# Altium schematic sheet POWER_PCie.SchDoc
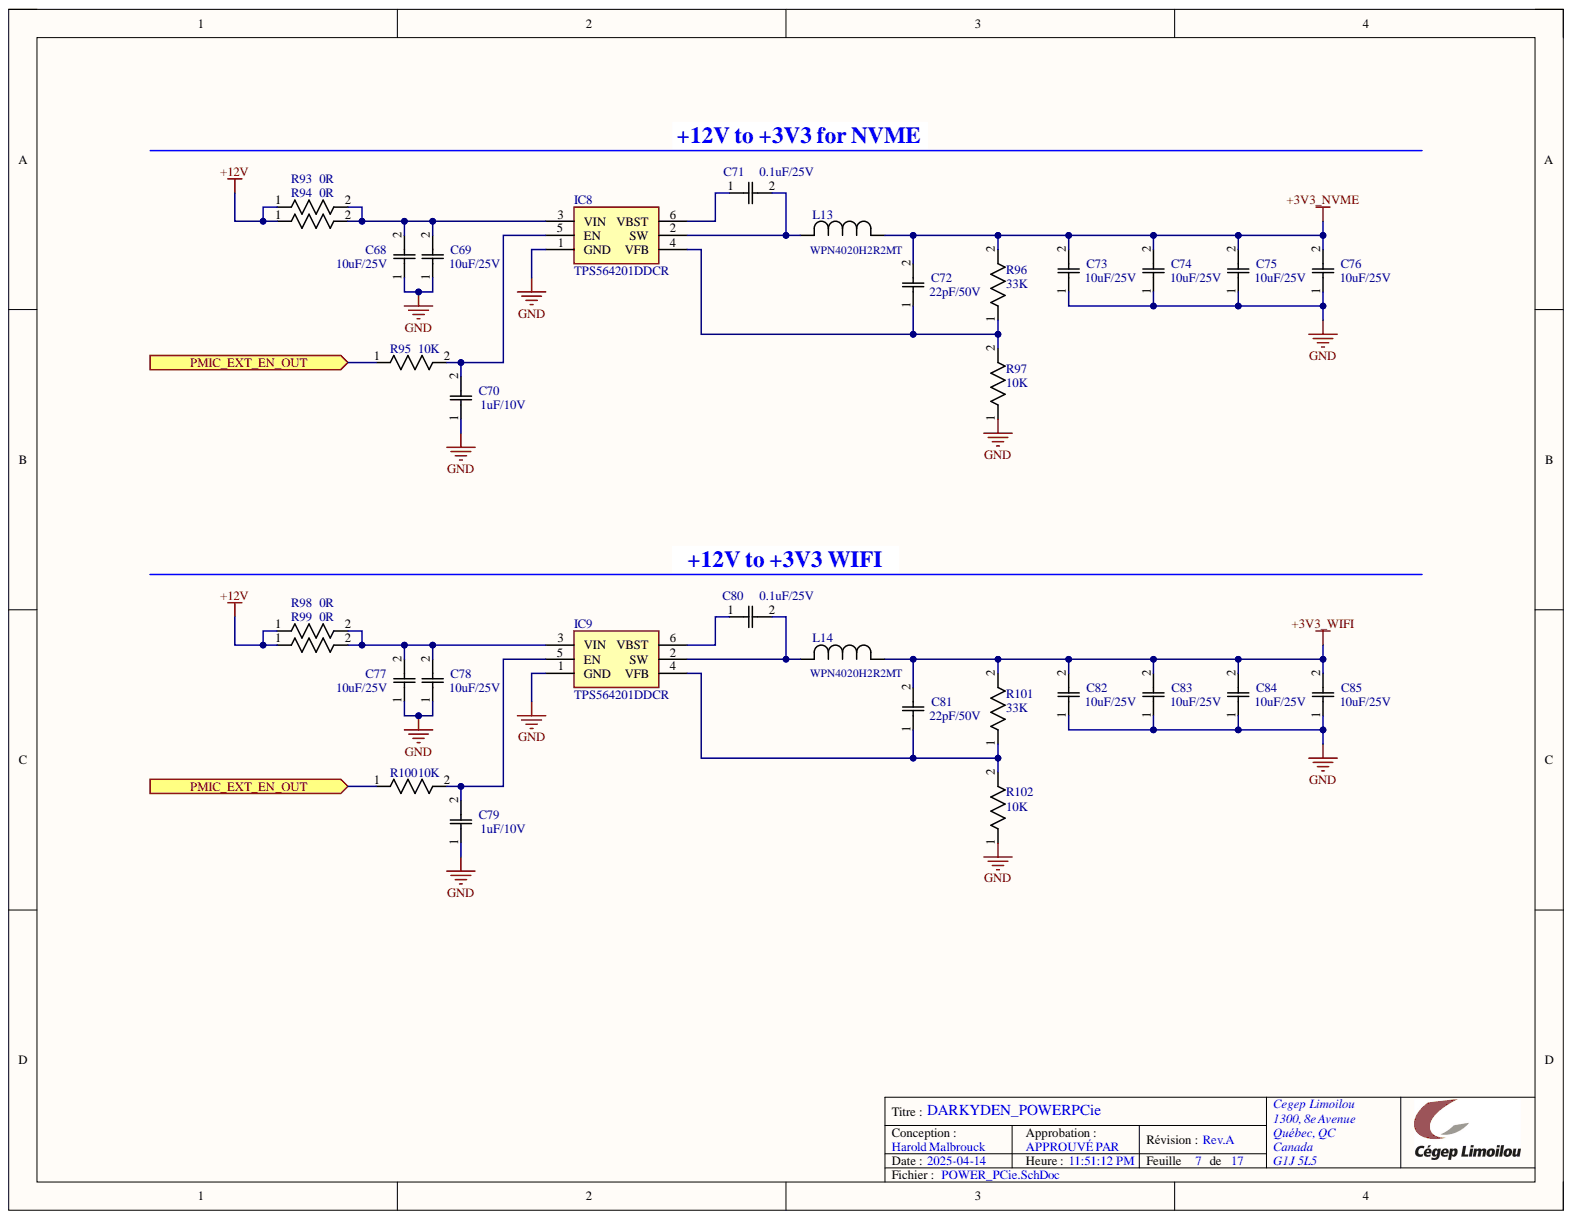
[diagram p0: POWER_PCie.SchDoc]

## Parts
C68  10uF/25V  [CAP_CC0805_YAG]
C69  10uF/25V  [CAP_CC0805_YAG]
C70  1uF/10V  [CAP_CC0805_YAG]
C71  0.1uF/25V  [CAP_CC0805_YAG]
C72  22pF/50V  [CAP_CC0805_YAG]
C73  10uF/25V  [CAP_CC0805_YAG]
C74  10uF/25V  [CAP_CC0805_YAG]
C75  10uF/25V  [CAP_CC0805_YAG]
C76  10uF/25V  [CAP_CC0805_YAG]
C77  10uF/25V  [CAP_CC0805_YAG]
C78  10uF/25V  [CAP_CC0805_YAG]
C79  1uF/10V  [CAP_CC0805_YAG]
C80  0.1uF/25V  [CAP_CC0805_YAG]
C81  22pF/50V  [CAP_CC0805_YAG]
C82  10uF/25V  [CAP_CC0805_YAG]
C83  10uF/25V  [CAP_CC0805_YAG]
C84  10uF/25V  [CAP_CC0805_YAG]
C85  10uF/25V  [CAP_CC0805_YAG]
IC8  TPS564201DDCR  [SOT95P280X110-6N]
IC9  TPS564201DDCR  [SOT95P280X110-6N]
L13  WPN4020H2R2MT  [IND_WPN4020H_SNL]
L14  WPN4020H2R2MT  [IND_WPN4020H_SNL]
R93  0R  [RES_0816_SUS]
R94  0R  [RES_0816_SUS]
R95  10K  [RES_0816_SUS]
R96  33K  [RES_0816_SUS]
R97  10K  [RES_0816_SUS]
R98  0R  [RES_0816_SUS]
R99  0R  [RES_0816_SUS]
R100  10K  [RES_0816_SUS]  (value not drawn)
R101  33K  [RES_0816_SUS]
R102  10K  [RES_0816_SUS]

## Ports
PMIC_EXT_EN_OUT

## Nets
+3V3_NVME: C72.2 C73.2 C74.2 C75.2 C76.2 L13.1 R96.2
+3V3_WIFI: C81.2 C82.2 C83.2 C84.2 C85.2 L14.1 R101.2
+12V: R93.1 R94.1 R98.1 R99.1
GND: C68.1 C69.1 C70.1 C73.1 C74.1 C75.1 C76.1 C77.1 C78.1 C79.1 C82.1 C83.1 C84.1 C85.1 IC8.1(GND) IC9.1(GND) R97.1 R102.1
PMIC_EXT_EN_OUT: R95.1 R100.1 ; port PMIC_EXT_EN_OUT
NetC68_2: C68.2 C69.2 IC8.3(VIN) R93.2 R94.2
NetC70_2: C70.2 IC8.5(EN) R95.2
NetC71_1: C71.1 IC8.6(VBST)
NetC71_2: C71.2 IC8.2(SW) L13.2
NetC72_1: C72.1 IC8.4(VFB) R96.1 R97.2
NetC77_2: C77.2 C78.2 IC9.3(VIN) R98.2 R99.2
NetC79_2: C79.2 IC9.5(EN) R100.2
NetC80_1: C80.1 IC9.6(VBST)
NetC80_2: C80.2 IC9.2(SW) L14.2
NetC81_1: C81.1 IC9.4(VFB) R101.1 R102.2

## Library data of the parts
C68-C85 = Capacitor_0805: No Description Available; Mfr_Name: Yageo; Component Kind: Standard; Library Name: Capacitor_0805.SchLib
IC8 IC9 = TPS564201DDCR: Integrated Circuit; Manufacturer_Name: Texas Instruments; Arrow Part Number: TPS564201DDCR; Arrow Price/Stock: https://www.arrow.com/en/products/tps564201ddcr/texas-instruments?region=nac; Component Kind: Standard; Datasheet Link: http://www.ti.com/lit/gpn/tps564201; Height: 1.1mm; Library Name: SamacSys.SchLib; Manufacturer_Part_Number: TPS564201DDCR; Mouser Part Number: 595-TPS564201DDCR; Mouser Price/Stock: https://www.mouser.co.uk/ProductDetail/Texas-Instruments/TPS564201DDCR?qs=zEmsApcVOkUKQpyzRnH%2FcQ%3D%3D
L13 L14 = WPN4020H2R2MT: No Description Available; Mfr_Name: Sunlord; Type: Fixed Inductors; Component Kind: Standard; Library Name: WPN4020H2R2MT.IntLib
R93-R102 = RG1608P-104-B-T5: No Description Available; Mfr_Name: Susumu; Component Kind: Standard; Library Name: RES_SMD_0603.SchLib
